# Altium schematic sheet Sheet3.SchDoc
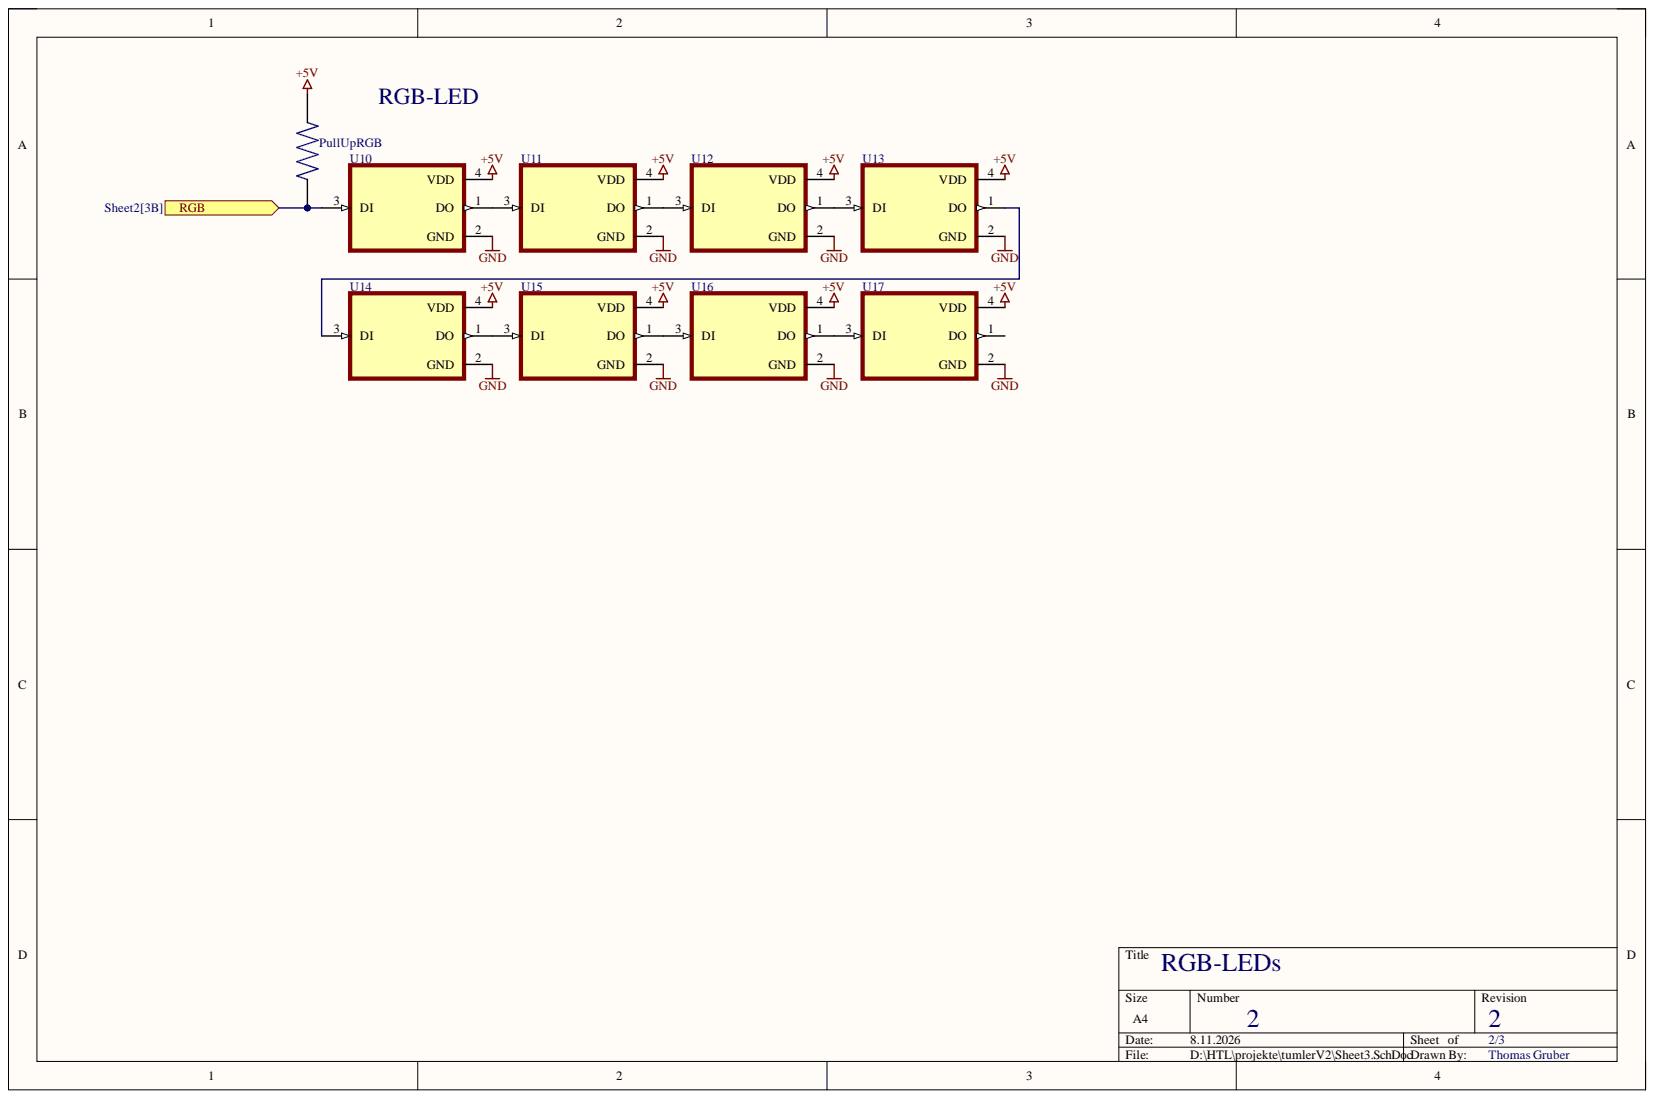
[diagram p0: Sheet3.SchDoc]

## Parts
PullUpRGB  0805W8F1002T5E  [RESC2012X65N]  (value not drawn)
U10  WS2812-2020  [LED_WS2812-2020]  (value not drawn)
U11  WS2812-2020  [LED_WS2812-2020]  (value not drawn)
U12  WS2812-2020  [LED_WS2812-2020]  (value not drawn)
U13  WS2812-2020  [LED_WS2812-2020]  (value not drawn)
U14  WS2812-2020  [LED_WS2812-2020]  (value not drawn)
U15  WS2812-2020  [LED_WS2812-2020]  (value not drawn)
U16  WS2812-2020  [LED_WS2812-2020]  (value not drawn)
U17  WS2812-2020  [LED_WS2812-2020]  (value not drawn)

## Ports
RGB

## Nets
+5V: PullUpRGB.2 U10.4(VDD) U11.4(VDD) U12.4(VDD) U13.4(VDD) U14.4(VDD) U15.4(VDD) U16.4(VDD) U17.4(VDD)
GND: U10.2(GND) U11.2(GND) U12.2(GND) U13.2(GND) U14.2(GND) U15.2(GND) U16.2(GND) U17.2(GND)
RGB: PullUpRGB.1 U10.3(DI) ; port RGB
NetU10_1: U10.1(DO) U11.3(DI)
NetU11_1: U11.1(DO) U12.3(DI)
NetU12_1: U12.1(DO) U13.3(DI)
NetU13_1: U13.1(DO) U14.3(DI)
NetU14_1: U14.1(DO) U15.3(DI)
NetU15_1: U15.1(DO) U16.3(DI)
NetU16_1: U16.1(DO) U17.3(DI)

Not connected: U17 1(DO)

## Library data of the parts
PullUpRGB = 0805W8F1002T5E: 10K Ohm 1/8W Thick Film Resistor 0805 Chip - Surface Mount; MF: Uni-Royal; Check_prices: https://www.snapeda.com/parts/0805W8F1002T5E/Uni-Royal/view-part/?ref=eda; Component Kind: Standard; MP: 0805W8F1002T5E; Package: 0805-2 ROYAL; SnapEDA_Link: https://www.snapeda.com/parts/0805W8F1002T5E/Uni-Royal/view-part/?ref=snap; Value: 10k
U10-U17 = WS2812-2020: Intelligent control LED light source, SMD-4; MF: Worldsemi; Availability: Not in stock; Check_prices: https://www.snapeda.com/parts/WS2812-2020/Worldsemi/view-part/?ref=eda; Component Kind: Standard; MP: WS2812-2020; Package: Package; Price: None; SnapEDA_Link: https://www.snapeda.com/parts/WS2812-2020/Worldsemi/view-part/?ref=snap
